# Altium schematic sheet NanoD1_Storage.SchDoc
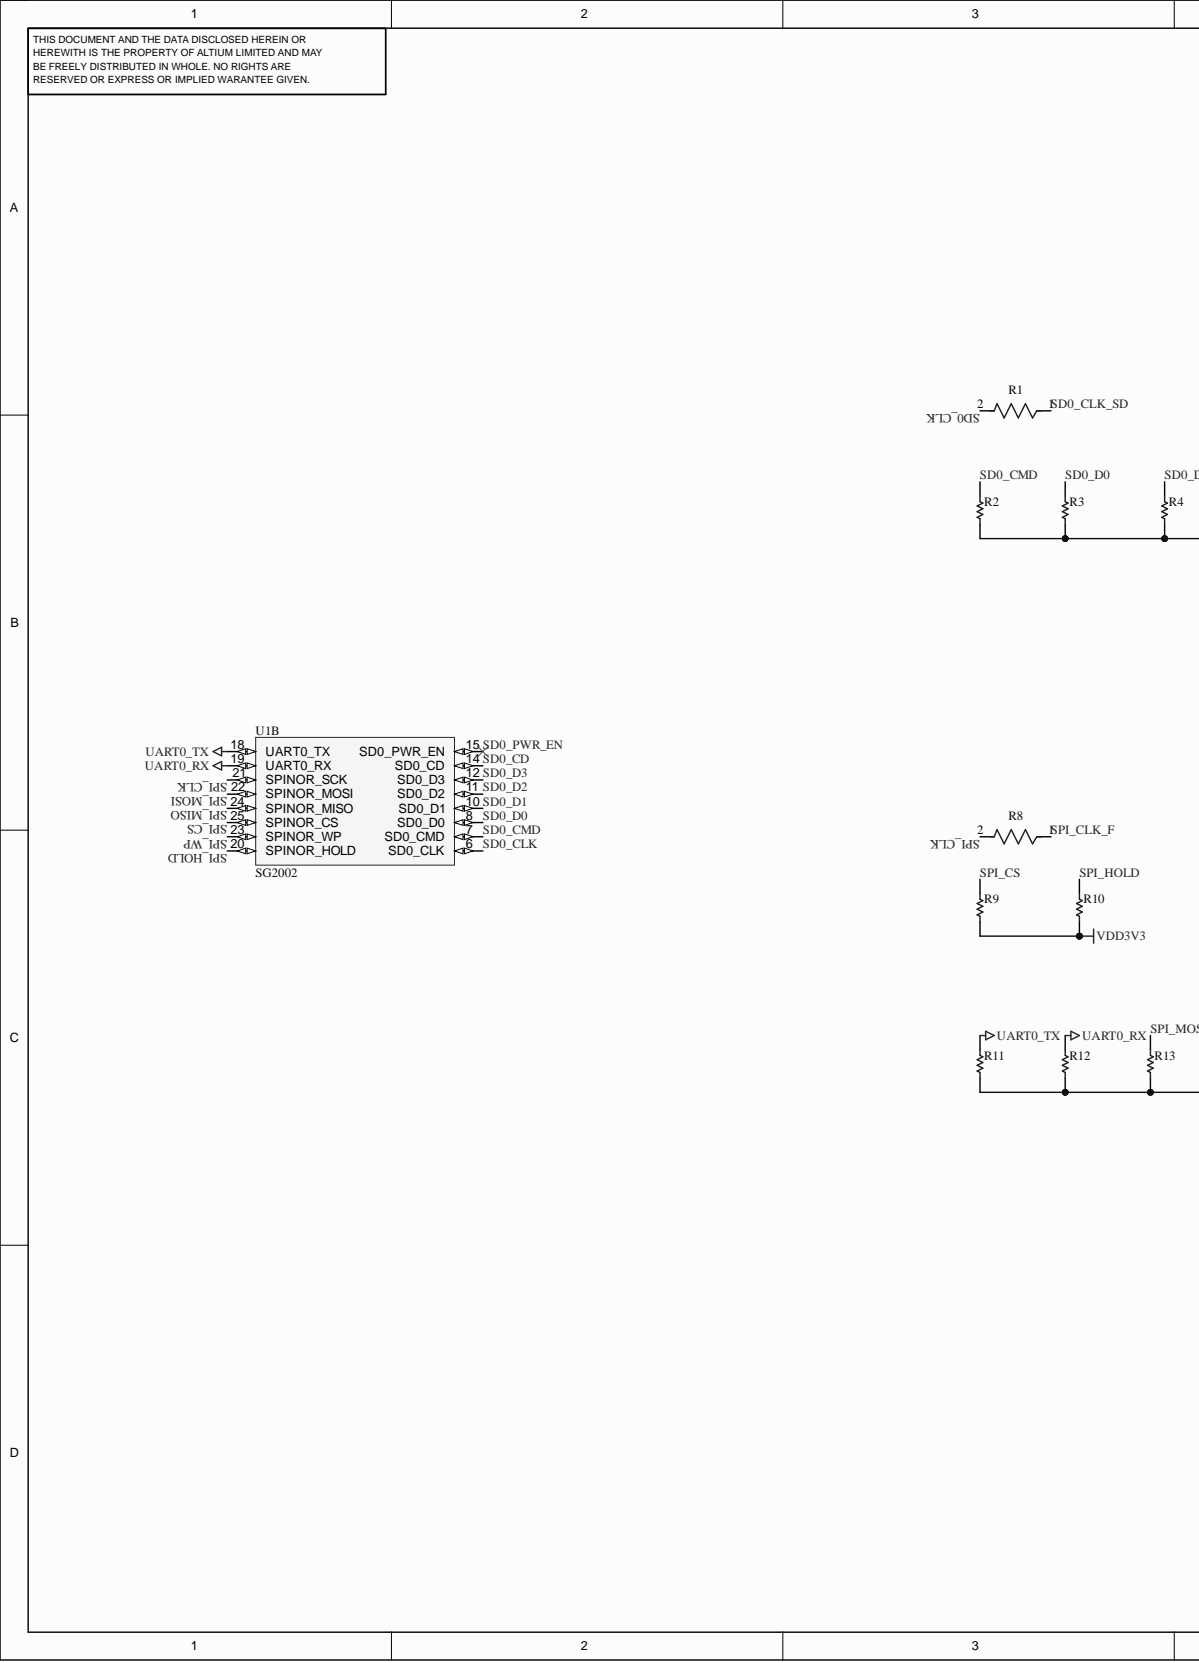
[diagram p0: NanoD1_Storage.SchDoc, left]
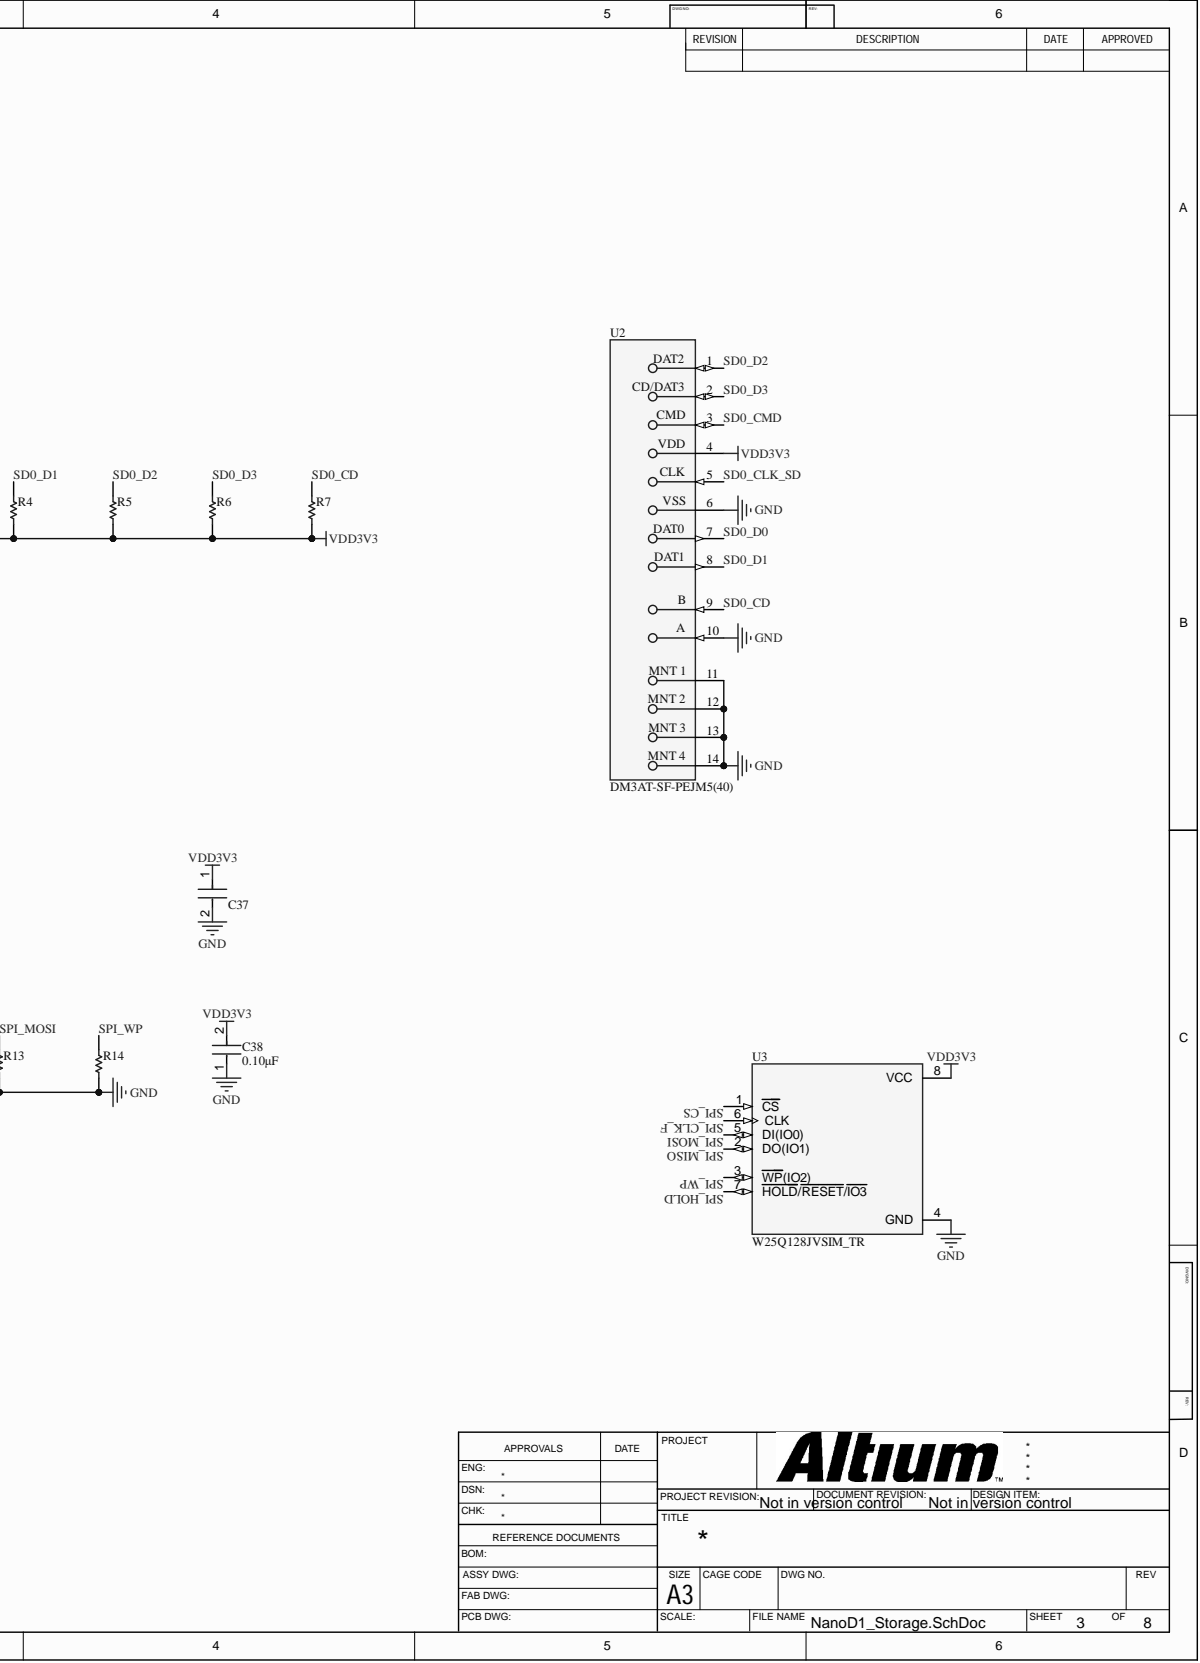
[diagram p1: NanoD1_Storage.SchDoc, right]

## Parts
C37  10uF  [FP-GRM188-0_15-IPC_B]  (value not drawn)
C38  value 0.10μF  Capacitance: 0.10μF  [FP-GRM15-MFG]  (hidden comment: 100nF)
R1  22R  [FP-RC0402-0_4-IPC_A]  (value not drawn)
R2  10K  [RESC1005X40X25ML05T10]  (value not drawn)
R3  10K  [RESC1005X40X25ML05T10]  (value not drawn)
R4  10K  [RESC1005X40X25ML05T10]  (value not drawn)
R5  10K  [RESC1005X40X25ML05T10]  (value not drawn)
R6  10K  [RESC1005X40X25ML05T10]  (value not drawn)
R7  10K 1%  [RESC1005X40X25ML05T10]  (value not drawn)
R8  22R  [FP-RC0402-0_4-IPC_A]  (value not drawn)
R9  4.7K  [RESC1005X40X25ML05T10]  (value not drawn)
R10  4.7K  [RESC1005X40X25ML05T10]  (value not drawn)
R11  4.7K  [RESC1005X40X25ML05T10]  (value not drawn)
R12  4.7K  [RESC1005X40X25ML05T10]  (value not drawn)
R13  4.7K  [RESC1005X40X25ML05T10]  (value not drawn)
R14  4.7K  [RESC1005X40X25ML05T10]  (value not drawn)
U1  SG2002  [QFN88-9x9-0.35]  (part B)
U2  DM3AT-SF-PEJM5(40)  [FP-DM3AT-SF-PEJM5_40-MFG]
U3  W25Q128JVSIM_TR  [SOIC127P790X216-8N]

## Nets
GND: C37.2 C38.1 R11.1 R12.1 R13.1 R14.1 U2.6 U2.10 U2.11 U2.12 U2.13 U2.14 U3.4(GND)
UART0_RX: R12.2 U1.19(UART0_RX)
UART0_TX: R11.2 U1.18(UART0_TX)
VDD3V3: C37.1 C38.2 R2.1 R3.1 R4.1 R5.1 R6.1 R7.1 R9.1 R10.1 U2.4 U3.8(VCC)
SD0_CD: R7.2 U1.14(SD0_CD) U2.9
SD0_CLK: R1.2 U1.6(SD0_CLK)
SD0_CLK_SD: R1.1 U2.5
SD0_CMD: R2.2 U1.7(SD0_CMD) U2.3
SD0_D0: R3.2 U1.8(SD0_D0) U2.7
SD0_D1: R4.2 U1.10(SD0_D1) U2.8
SD0_D2: R5.2 U1.11(SD0_D2) U2.1
SD0_D3: R6.2 U1.12(SD0_D3) U2.2
SD0_PWR_EN: U1.15(SD0_PWR_EN)
SPI_CLK: R8.2 U1.21(SPINOR_SCK)
SPI_CLK_F: R8.1 U3.6(CLK)
SPI_CS: R9.2 U1.25(SPINOR_CS) U3.1(CS)
SPI_HOLD: R10.2 U1.20(SPINOR_HOLD) U3.7(HOLD/RESET/IO3)
SPI_MISO: U1.24(SPINOR_MISO) U3.2(DO(IO1))
SPI_MOSI: R13.2 U1.22(SPINOR_MOSI) U3.5(DI(IO0))
SPI_WP: R14.2 U1.23(SPINOR_WP) U3.3(WP(IO2))

## Library data of the parts
C37 = CMP-06035-003612-1: Chip Multilayer Ceramic Capacitors for General Purpose, 0603, 10uF, X5R, 15%, 20%, 10V; Capacitance: 10uF; Case Code (Imperial): 0603; Case Code (Metric): 1608; Case/Package: 0603; Component Kind: Standard; Depth: 0.8mm; Dielectric: X5R; Height: 0.8mm; Lead Free: Lead Free; Length: 1.6mm; Library Name: GRM188R61A106ME69D.IntLib; Material: Ceramic; Max Operating Temperature: 85°C; Min Operating Temperature: -55°C; Mount: Surface Mount; Package Quantity: 4000; Packaging: Tape and Reel; Termination: SMD/SMT; Thickness: 0.035inch; Tolerance: 20%; Voltage Rating: 10V; Voltage Rating (DC): 10V; Weight: 0.000222oz; Width: 0.8mm
C38 = CMP-2100-03641-2: CAP CER 0.1UF 16V X7R 0402; Manufacturer: Murata; Manufacturer Part Number: GRM155R71C104KA88D; Material: Ceramic; Standoff Height: 0mm; Tolerance: 10%; Voltage Rating: 16Vdc; Category: Cap; Component Kind: Standard; ComponentLink2Description: Datasheet; ComponentLink2URL: https://search.murata.co.jp/Ceramy/image/img/A01X/G101/ENG/GRM155R71H471KA01-01A.pdf; Device Class L1: Passive Components; Device Class L2: Capacitors; Device Class L3: Ceramic Capacitors; Digikey Description: CAP CER 0.1UF 16V X7R 0402; Height: 0.55mm; Ipc Land Pattern Name: CAPC10050X219; Lead Free: yes; Library Name: GRM155R71C104KA88D.IntLib; Mouser Description: 0402 0.1 uF 16V ±10 % Tolerance X7R SMT Multilayer Ceramic Capacitor; Octopart: https://octopart.com/grm155r71c104ka88d-murata-196880; Package: GRM15; RoHS: TRUE; Temperature: -55°C to +125°C; Temperature Characteristic: X7R; Temperature Range High: +125°C; Temperature Range Low: -55°C
R1 R8 = CMP-2002-07520-2: Power Rating: 63mW; Resistance: 22Ω; Temperature Coefficient: 100ppm/°C; Tolerance: 1%; Voltage Rating: 50V; Case Code (Imperial): 0402; Case/Package: 0402; Component Kind: Standard; Composition: Thick Film; Depth: 500µm; Height: 400µm; Lead Free: Lead Free; Length: 1mm; Library Name: RC0402FR-0722RL.IntLib; Max Operating Temperature: 155°C; Military Standard: Not; Min Operating Temperature: -55°C; Number of Pins: 2; Packaging: Tape & Reel; REACH SVHC: Unknown; RoHS: Compliant; Schedule B: 8533210045, 8533210045|8533210045|8533210045|8533210045|8533210045|8533210045|8533210045|8533210045, 8533210045|8533210045|8533210045|8533210045|8533210045|8533210045|8533210045|8533210045|8533210045, 8533210045|8533210045|8533210045|8533210045|8533210045|8533210045|8533210045|8533210045|8533210045|8533210045; Termination: SMD/SMT; Voltage Rating (AC): 50V; Voltage Rating (DC): 50V; Width: 500µm
R2-R7 = CMP-2002-07353-1: Manufacturer: Yageo / Phycomp; Part Number: RC0402FR-0710KL; Component Kind: Standard; Library Name: RC0402FR-0710KL.IntLib
R9-R14 = CMP-1011-00586-2: Manufacturer: Yageo / Phycomp; Part Number: RC0402FR-074K7L; Component Kind: Standard; Library Name: RC0402FR-074K7L.IntLib
U1 = SG2002: RISC-V C906 / Cortex-A53 SoC, 256MB DDR3 SiP, QFN88 9x9 0.35mm; Component Kind: Standard; Library Name: NanoD1.SchLib
U2 = CMP-11597-000006-1: CONN MICRO SD R/A PUSH-PUSH SMD; Connector Type: Connector; Contact Resistance: 100mR; Current Rating: 500mA; Gender: Receptacle; Insulation Resistance: 1GR; Mating Cycles: 10000; Max Voltage Rating (AC): 125V; Number of Contacts: 8; Pitch: 1.1mm; Color: Black; Component Kind: Standard; Contact Material: Copper Alloy; Contact Plating: Gold; Depth: 15.95mm; Flammability Rating: UL94 V-0; Housing Material: Polymer; Lead Free: Lead Free; Length: 13.85mm; Library Name: DM3AT-SF-PEJM5(40).IntLib; Max Current Rating: 500mA; Max Operating Temperature: 85°C; Min Operating Temperature: -25°C; Mount: Surface Mount; Number of Rows: 2; Orientation: Right Angle; Package Quantity: 400; Packaging: Tape and Reel; Radiation Hardening: No; REACH SVHC: No SVHC; Series: DM3; Termination: Solder; Voltage Rating: 125V; Voltage Rating (AC): 125V; Width: 13.85mm
U3 = W25Q128JVSIM_TR: FLASH - NOR Memory IC 128Mb (16M x 8) SPI - Quad I/O, QPI, DTR 133 MHz 8-SOIC; MF: Winbond Electronics; MP: W25Q128JVSIM TR; Check_prices: https://www.snapeda.com/parts/W25Q128JVSIM%20TR/Winbond/view-part/?ref=eda; Component Kind: Standard; Library Name: W25Q128JVSIM_TR.IntLib; Package: SOIC-8 Winbond Electronics; SnapEDA_Link: https://www.snapeda.com/parts/W25Q128JVSIM%20TR/Winbond/view-part/?ref=snap
